# Altium schematic sheet RA_64pin_Local_Promotion_Board_2.SchDoc(RA_64pin_Local_Promotion_Board_2)
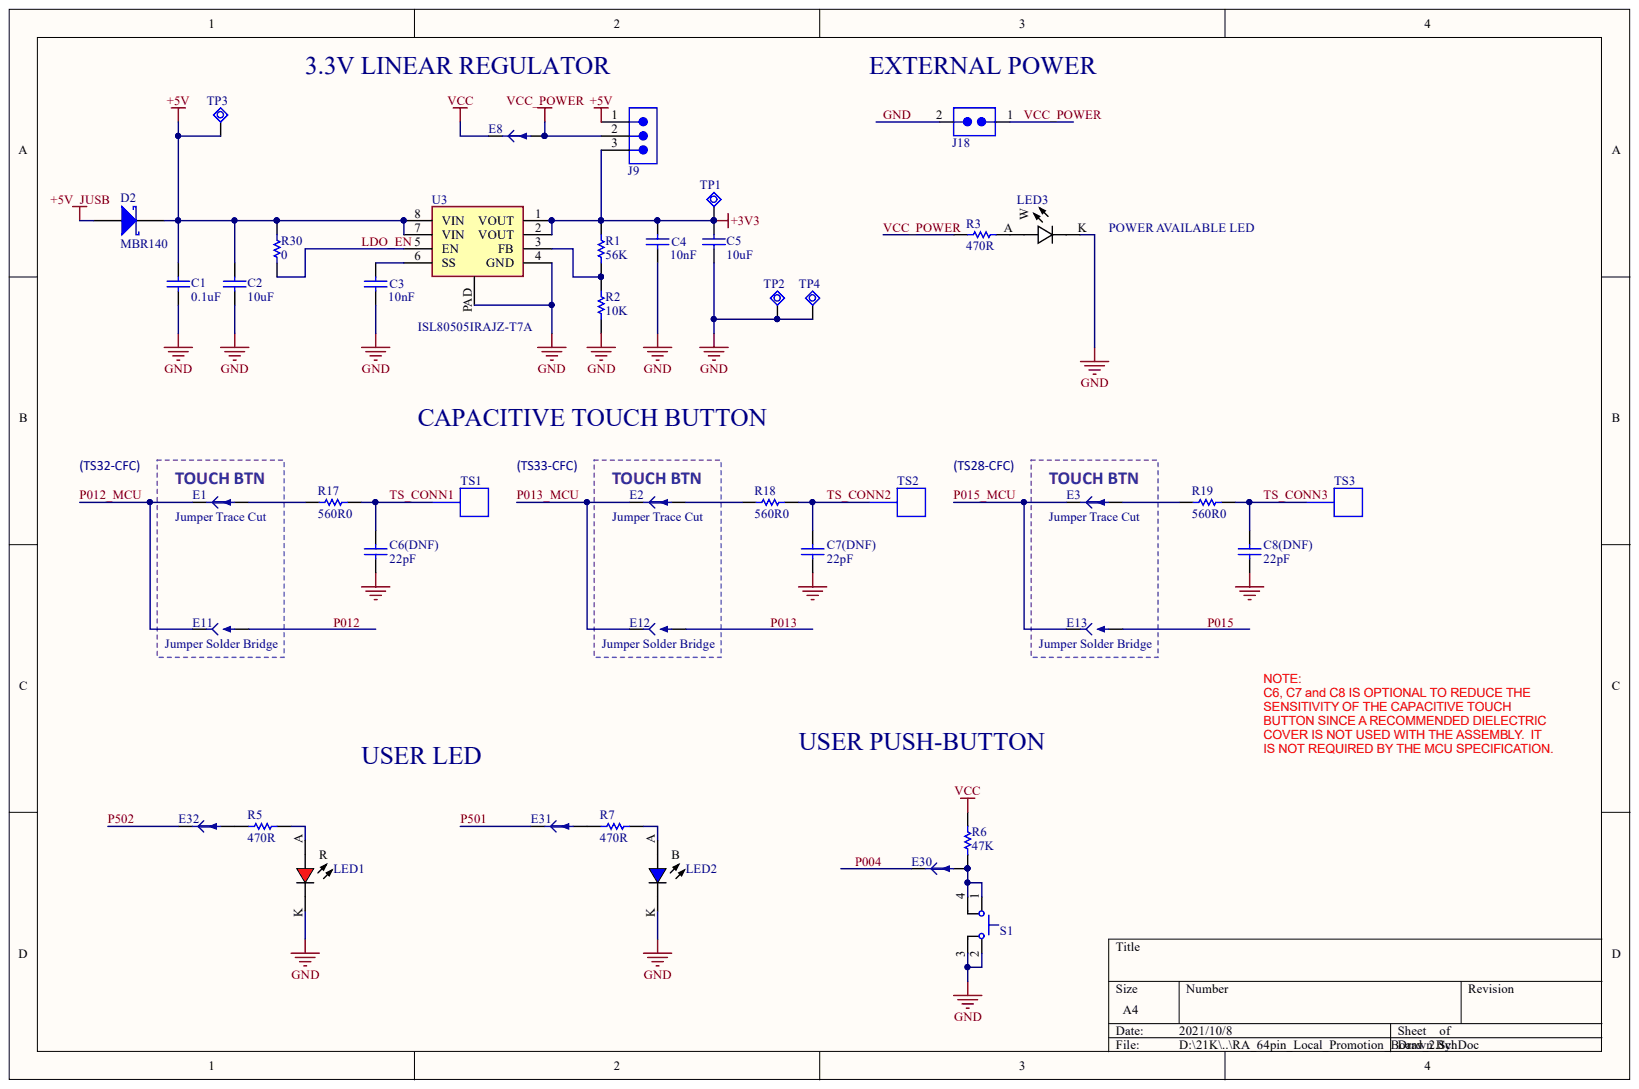
[diagram p0: RA_64pin_Local_Promotion_Board_2.SchDoc]

## Parts
C1  0.1uF  [CAPC0603-01]
C2  10uF  [CAPC0603-01]
C3  10nF  [CAPC0603-01]
C4  10nF  [CAPC0603-01]
C5  10uF  [CAPC0603-01]
C6(DNF)  Capacitance: 22pF  [CAPC0603-01]  (hidden comment: CAP-R01002-01)
C7(DNF)  Capacitance: 22pF  [CAPC0603-01]  (hidden comment: CAP-R01002-01)
C8(DNF)  Capacitance: 22pF  [CAPC0603-01]  (hidden comment: CAP-R01002-01)
D2  Display Name: MBR140  [ONSEMI_SOD-123LF]  (hidden comment: MBR140SFT1G)
E1  Jumper Trace Cut  [E_PadsTraceCut0805]
E2  Jumper Trace Cut  [E_PadsTraceCut0805]
E3  Jumper Trace Cut  [E_PadsTraceCut0805]
E8  (no value)  [E_PadsTraceCut0805]
E11  Jumper Solder Bridge  [E_PadsSplit0805]  (no BOM)
E12  Jumper Solder Bridge  [E_PadsSplit0805]  (no BOM)
E13  Jumper Solder Bridge  [E_PadsSplit0805]  (no BOM)
E30  Jumper Trace Cut  [E_PadsTraceCut0805]  (no BOM)
E31  Jumper Trace Cut  [E_PadsTraceCut0805]  (no BOM)
E32  Jumper Trace Cut  [E_PadsTraceCut0805]  (no BOM)
J9  2.54mm 1x3  [FCI_6800-x03]  (value not drawn)
J18  2.54mm 1x2  [CON-2P-2.54]  (value not drawn)
LED1  #NAME?  [LC-0603_LED_S1]  (value not drawn)
LED2  #NAME?  [LC-0603_LED_S1]  (value not drawn)
LED3  (no value)  [LC-0603_LED_S1]
R1  56K  [RESC0603-01]
R2  10K  [RESC0603-01]
R3  470R  [RESC0603-01]
R5  470R  [RESC0603-01]
R6  47K  [RESC0603-01]
R7  470R  [RESC0603-01]
R17  Resistance: 560R0  [RESC0603-01]  (hidden comment: RES-000206-01)
R18  Resistance: 560R0  [RESC0603-01]  (hidden comment: RES-000206-01)
R19  Resistance: 560R0  [RESC0603-01]  (hidden comment: RES-000206-01)
R30  10K  [RESC0603-01]  (value not drawn)
S1  Switch 4.5x4.5mm  [KEY A (4.5 X 4.5)]  (value not drawn)
TP1  Test Point  [TP A - Red]  (value not drawn)
TP2  Test Point  [TP A - BLK]  (value not drawn)
TP3  Test Point  [TP A - Red]  (value not drawn)
TP4  Test Point  [TP A - BLK]  (value not drawn)
TS1  TOUCH_PAD_OL  [TestP]  (value not drawn)  (no BOM)
TS2  TOUCH_PAD_OL  [TestP]  (value not drawn)  (no BOM)
TS3  TOUCH_PAD_OL  [TestP]  (value not drawn)  (no BOM)
U3  ISL80505IRAJZ  Display Name: ISL80505IRAJZ-T7A  [DFN8 (3x3) Pitch 0.65]

## Nets
+3V3: C4.2 C5.2 J9.3 R1.2 TP1.1 U3.1(VOUT) U3.2(VOUT)
+5V: C1.2 C2.2 D2.K J9.1 R30.1 TP3.1 U3.7(VIN) U3.8(VIN)
+5V_JUSB: D2.A
VCC: E8.1 R6.2
GND: C1.1 C2.1 C3.1 C4.1 C5.1 C6(DNF).1 C7(DNF).1 C8(DNF).1 J18.2 LED1.K LED2.K LED3.K R2.1 S1.2 S1.3 TP2.1 TP4.1 U3.4(GND) U3.PAD
LDO_EN: R30.2 U3.5(EN)
P004: E30.1
P012: E11.2
P012_MCU: E1.1 E11.1
P013: E12.2
P013_MCU: E2.1 E12.1
P015: E13.2
P015_MCU: E3.1 E13.1
P501: E31.1
P502: E32.1
TS_CONN1: C6(DNF).2 R17.2
TS_CONN2: C7(DNF).2 R18.2
TS_CONN3: C8(DNF).2 R19.2
VCC_POWER: E8.2 J9.2 J18.1 R3.1
NetC3_2: C3.2 U3.6(SS)
NetE1_2: E1.2 R17.1
NetE2_2: E2.2 R18.1
NetE3_2: E3.2 R19.1
NetE30_2: E30.2 R6.1 S1.1 S1.4
NetE31_2: E31.2 R7.1
NetE32_2: E32.2 R5.1
NetLED1_A: LED1.A R5.2
NetLED2_A: LED2.A R7.2
NetLED3_A: LED3.A R3.2
NetR1_1: R1.1 R2.2 U3.3(FB)

## Library data of the parts
C1 = CAP-0603-0.1uF: Ceramic Chip Capacitor 0603 0.1uF 50V; Manufacturer: MURATA; Mfg Part Number: GCM188L81H104KA57D; Dialectric: X8L; Tolerance: 10%; Capacitance: 0.1uF; Component Kind: Standard; Library Name: New Com.SchLib; Package: 0603; Voltage: 50V
C2 C5 = CAP-0603-10uF: Ceramic Chip Capacitor 0603 10uF 6.3V; Manufacturer: Samsung; Mfg Part Number: CL10A106MQ8NNNC; Dialectric: X5R; Tolerance: 20%; Capacitance: 10uF; Component Kind: Standard; Package: 0603; Voltage: 6.3V; Library Name: Synergy.SchLib
C3 C4 = CAP-0603-10nF: Ceramic Chip Capacitor 0603 10nF 50V; Manufacturer: MURATA; Mfg Part Number: GCD188R71H103KA01D; Dialectric: X7R; Tolerance: 10%; Capacitance: 10nF; Component Kind: Standard; Library Name: New Com.SchLib; Package: 0603; Voltage: 50V
C6(DNF) C7(DNF) C8(DNF) = CAP-R01002-01: Ceramic Chip Capacitor 0603 22pF 50V; Manufacturer: AVX; Mfg Part Number: 06035A220JAT2A; Dialectric: COG; Tolerance: 5%; Component Kind: Standard; Library Name: SpecialPartsLib.SchLib; Package: 0603; Voltage: 50V
D2 = DIO-MBR140SFT1G: OnSemi Schottky Power Rectifier 40V 1A; Component Kind: Standard; Manufacturer: OnSemi; Mfg Part Number: MBR140SFT1G; Package: SOD-123FL; Library Name: Synergy.SchLib
E1-E3 E8 E30-E32 = E_JmpTraceCut: Pads on PCB with Trace Between for Cutting to isolate
  E1-E3 E8: Component Kind: Net Tie; Library Name: TB_SpecialLib.SchLib
  E30-E32: Part Type: Jumper Trace Cut; Library Name: Synergy.SchLib
E11-E13 = E_JmpSldrBridge: Pads on PCB with Narrow Gap to allow Solder Bridging; Library Name: TB_SpecialLib.SchLib
J9 = CON-2.54mm 1x3: Header 0.1" pitch 3pos 1x3; Manufacturer: FCI; Mfg Part Number: 77311-818-03LF; Positions: 3; Component Kind: Standard; Pitch: 0.1"; Library Name: Synergy.SchLib
J18 = CON-XH2.54mm 1x2: FCI Header 0.1" pitch 2pos 1x2; Mfg Part Number: 67997-402HLF; Positions: 2; Component Kind: Standard; Manufacturer: FCI; Pitch: 2.54mm; Library Name: Synergy.IntLib
LED1 = LED-000015-02: Wurth Elektronik LED RED Clear 0603; Mfg Part Number: 150060RS75000; ClassName: User-LED-R; Color: Red; Component Kind: Standard; Library Name: Semiconductors.IntLib; Manufacturer: Wurth Elektronik; Manufacturer 1: Wurth Elektronik; Manufacturer Part Number 1: #NAME?; Package: 0603; Supplier 1: Digi-Key; Supplier Part Number 1: 732-4978-1-ND
LED2 = LED-000013-02: Wurth Elektronik LED Blue Clear 0603; Mfg Part Number: 150060BS75000; ClassName: User-LED-B; Color: Blue; Component Kind: Standard; Library Name: Semiconductors.IntLib; Manufacturer: Wurth Elektronik; Manufacturer 1: Wurth Elektronik; Manufacturer Part Number 1: #NAME?; Package: 0603; Supplier 1: Digi-Key; Supplier Part Number 1: 732-4966-1-ND
LED3 = LED-000012-02: Lite-On LED White, Yellow lens 0603 - VERTICAL; Manufacturer Part Number 1: #NAME?; Mfg Part Number: LTW-C191DS5; ClassName: Power-LED; Color: White; Component Kind: Standard; Library Name: Semiconductors.IntLib; Manufacturer: Lite-On; Manufacturer 1: Lite-On; Package: 0603; Supplier 1: Digi-Key; Supplier Part Number 1: 160-2239-1-ND
R1 = RES-0603-56K: Chip Resistor Thick Film 0603 56K 1% 1/10W; Manufacturer: YAGEO; Mfg Part Number: RC0603FR-0756KL; Component Kind: Standard; Library Name: New Com.SchLib; Package: 0603; Power: 1/10W; Resistance: 56K; Tolerance: 1%
R2 = RES-0603-10K: Chip Resistor Thick Film 0603 10K 1% 1/10W; Manufacturer: YAGEO; Mfg Part Number: RC0603FR-0710KL; Component Kind: Standard; Library Name: New Com.SchLib; Package: 0603; Power: 1/10W; Resistance: 10K; Tolerance: 1%
R3 R5 R7 = RES-0603-470R: Chip Resistor Thick Film 0603 470R 1% 1/10W; Manufacturer: YAGEO; Mfg Part Number: RC0603FR-07470RL; Component Kind: Standard; Library Name: New Com.SchLib; Package: 0603; Power: 1/10W; Resistance: 470R; Tolerance: 1%
R6 = RES-0603-47K: Chip Resistor Thick Film 0603 47K 1% 1/10W; Manufacturer: YAGEO; Mfg Part Number: RC0603FR-0747KL; Component Kind: Standard; Library Name: New Com.SchLib; Package: 0603; Power: 1/10W; Resistance: 47K; Tolerance: 1%
R17-R19 = RES-000206-01: Chip Resistor Thick Film 0603 560R0 1% 1/10W; Manufacturer: Panasonic; Mfg Part Number: ERJ-3EKF5600V; Component Kind: Standard; Library Name: Resistors.IntLib; Package: 0603; Power: 1/10W; Serious P/N: RES-000206-01; Tolerance: 1%
R30 = RES-0603-10K: Chip Resistor Thick Film 0603 10K 1% 1/10W; Manufacturer: YAGEO; Mfg Part Number: RC0603FR-0710KL; Resistance: 0; Component Kind: Standard; Library Name: New Com.SchLib; Package: 0603; Power: 1/10W; Tolerance: 1%
S1 = SWT-4.5x4.5mm: Push Button Switch 4.5 x 4.5mm 3.8mm High; Manufacturer: C&K; Mfg Part Number: PTS647SM38SMTR2 LFS; Component Kind: Standard; Library Name: New Com.SchLib
TP1-TP4 = CON-Test Point: Component Kind: Standard; Description: Test Point; Library Name: Synergy.SchLib
TS1-TS3 = TOUCH_PAD_OL: Renesas Touch Sense Pad Outline (for non-Pad use); Library Name: SpecialPartsLib.SchLib
U3 = ICN-ISL80510: IC REG LINEAR POS ADJ 1A 8-DFN（3x3）; Manufacturer: Intersil; Component Kind: Standard; Mfg Part Number: ISL80505IRAJZ-T7A; Package: 8-VDFN; Library Name: Synergy.SchLib
